# Altium schematic sheet Power.SchDoc(Power)
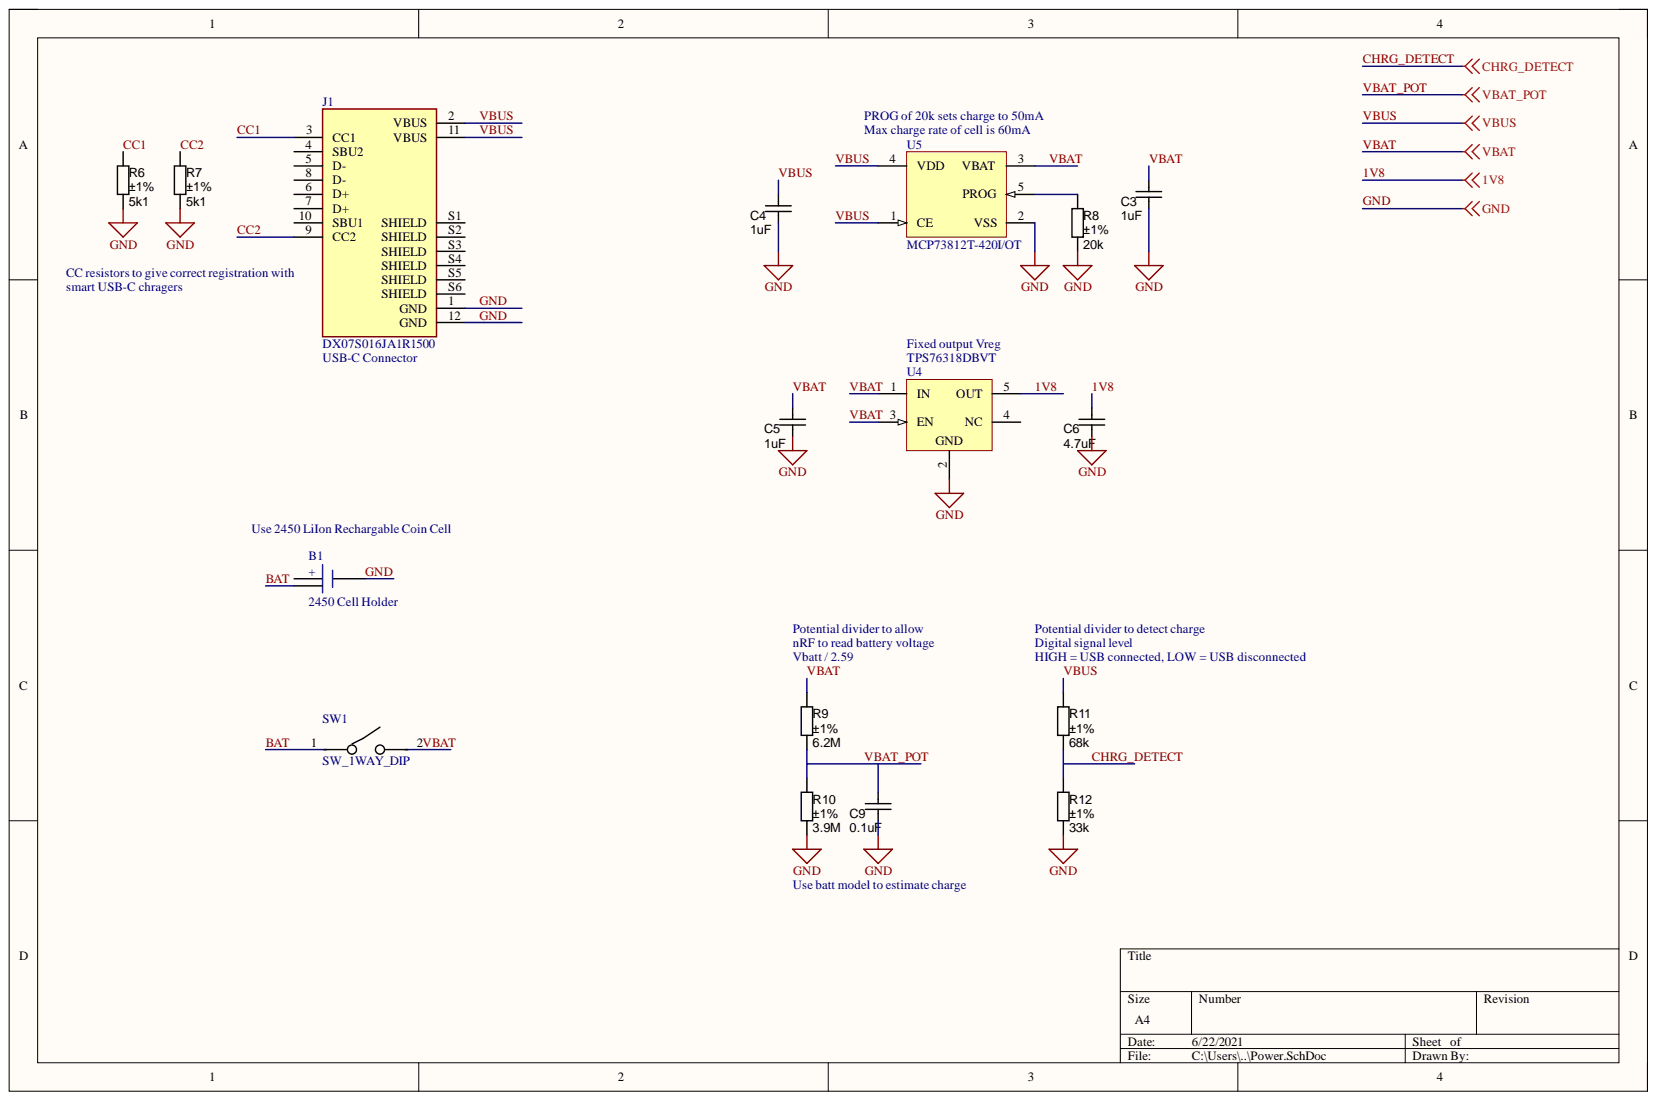
[diagram p0: Power.SchDoc]

## Parts
B1  2450 Cell Holder  [BC-2404]
C3  value 1uF  [CAPC1608X90N]  (hidden comment: approved sub OK)
C4  value 1uF  [CAPC1608X90N]  (hidden comment: approved sub OK)
C5  value 1uF  [CAPC1608X90N]  (hidden comment: approved sub OK)
C6  value 4.7uF  [CAPC1608X90N]  (hidden comment: approved sub OK)
C9  value 0.1uF  [CAPC1608X90N]  (hidden comment: approved sub OK)
J1  DX07S016JA1R1500  [JAE_DX07S016JA1R1500]
R6  value 5k1  Tolerance: ±1%  [RESC1608X55N]  (hidden comment: Thick Film)
R7  value 5k1  Tolerance: ±1%  [RESC1608X55N]  (hidden comment: Thick Film)
R8  value 20k  Tolerance: ±1%  [RESC1608X55N]  (hidden comment: Thick Film)
R9  value 6.2M  Tolerance: ±1%  [RESC1608X55N]  (hidden comment: Thick Film)
R10  value 3.9M  Tolerance: ±1%  [RESC1608X55N]  (hidden comment: Thick Film)
R11  value 68k  Tolerance: ±1%  [RESC1608X55N]  (hidden comment: Thick Film)
R12  value 33k  Tolerance: ±1%  [RESC1608X55N]  (hidden comment: Thick Film)
SW1  SW_1WAY_DIP  [SW_1WAY]
U4  TPS76318DBVT  [TI-DBV5_N]
U5  MCP73812T-420I/OT  [SOT-23-OT5_N]

## Nets
1V8: C6.1 U4.5(OUT)
BAT: B1.3 SW1.1
CC1: J1.3(CC1) R6.1
CC2: J1.9(CC2) R7.1
CHRG_DETECT: R11.2 R12.1
GND: B1.2 C3.2 C4.2 C5.2 C6.2 C9.2 J1.1(GND) J1.12(GND) R6.2 R7.2 R8.2 R10.2 R12.2 U4.2 U5.2(VSS)
VBAT: C3.1 C5.1 R9.1 SW1.2 U4.1(IN) U4.3(EN) U5.3(VBAT)
VBAT_POT: C9.1 R9.2 R10.1
VBUS: C4.1 J1.2(VBUS) J1.11(VBUS) R11.1 U5.1(CE) U5.4(VDD)
NetJ1_8: J1.8(D-)
NetR8_1: R8.1 U5.5(PROG)

Not connected: B1 1; J1 4(SBU2) 5(D-) 6(D+) 7(D+) 10(SBU1) S1(SHIELD) S2(SHIELD) S3(SHIELD) S4(SHIELD) S5(SHIELD) S6(SHIELD); U4 4(NC)

## Library data of the parts
B1 = 2450-LiIon: Multicomp BC-2404; Component Kind: Standard; Library Name: Light Sensor Prototype.SchLib
C3-C6 C9 = CAPC_100n_0603_X7R_50V_10%: Capacitor SMD Cer 10uF 10% 16V X5R 0603; Manufacturer: TDK; Manufacturer Part Number: C1608X5R1C106M080AB; Material: ceramic; Ratings: 16V; X5R; Component Kind: Standard; Package / Case: 0603 (1608 Metric); Supplier: Farnell; Supplier Link: https://uk.farnell.com/tdk/c1608x5r1c106m080ab/cap-10-f-16v-20-x5r-0603/dp/2525096?st=2521959; Supplier Part Number: 2525096; Tolerance: ±10%; Library Name: UEKAE.SCHLIB
J1 = DX07S016JA1R1500: USB-C (USB TYPE-C) USB 2.0 Receptacle Connector 24 (16+8 Dummy) Position Surface Mount, Right Angle; Through Hole; MF: JAE Electronics; Availability: Unavailable; Component Kind: Standard; DigiKey-Purchase-URL: https://snapeda.com/shop?store=DigiKey&id=4551013; Library Name: DX07S016JA1R1500.IntLib; MP: DX07S016JA1R1500; Package: None; Price: None; SnapEDA_Link: https://www.snapeda.com/parts/DX07S016JA1R1500/JAE%20Electronics/view-part/4551013/?ref=snap
R6-R12 = RES_10K_0603_1%: Resistor SMD chip 10k Ohm 0.1W 1% 0603; Manufacturer 1: Yageo; Manufacturer Part Number 1: RC0603FR-0710KL; Ratings: 1/10W; SCDType: spec; Component Kind: Standard; Package / Case: 0603 (1608 Metric); Supplier 1: Digi-Key; Supplier Part Number 1: 311-10.0KHRCT-ND; Library Name: Libs_RRutledge.IntLib
U4 = TPS76318DBVT: Single Output LDO, 150 mA, Fixed 1.8 V Output, 2.7 to 10 V Input, with Low IQ, 5-pin SOT-23 (DBV), -40 to 125 degC, Green (RoHS & no Sb/Br); Manufacturer: Texas Instruments; Accuracy(%): 3; Fixed Output Options(V): 1.8; Iout(Max)(A): 0.15; Iq(Typ)(mA): 0.08; SubFamily: Single Channel LDO; Vdo(Typ)(mV): 300; Vin(Max)(V): 10; Vin(Min)(V): 2.7; Code_JEDEC: MO-178; Component Kind: Standard; ComponentLink1Description: Manufacturer URL; ComponentLink1URL: http://www.ti.com/; ComponentLink2Description: Datasheet; ComponentLink2URL: http://www.ti.com/general/docs/lit/getliterature.tsp?genericPartNumber=TPS76318&fileType=pdf; ComponentLink3Description: Package Specification; ComponentLink3URL: http://www.ti.com/litv/pdf/mpds018i; DatasheetVersion: SLVS181H; Eco-Plan: Green (RoHS & no Sb/Br); Library Name: TI Power Management Linear Regulator Single Channel LDO (0-350mA).IntLib; Mounting Technology: Surface Mount; PackageDescription: 5-Pin Plastic Small Outline, Body 1.60 Ã— 2.90 mm, Pitch 0.95 mm; PackageReference: DBV5; PackageVersion: 4073253-4/K; PartNumber: TPS76318DBVT; Supplier 1: Digi-Key; Supplier 2: Digi-Key; Supplier 3: Digi-Key; Supplier 4: Farnell; Supplier 5: Farnell; Supplier 6: Newark; Supplier 7: Mouser; Supplier Part Number 1: 296-2700-2-ND; Supplier Part Number 2: 296-2700-1-ND; Supplier Part Number 3: 296-2700-6-ND; Supplier Part Number 4: 8461341RL; Supplier Part Number 5: 8461341; Supplier Part Number 6: 96C8608; Supplier Part Number 7: 595-TPS76318DBVT; Vout Adj(Max)(V): 1.8; Vout Adj(Min)(V): 1.8
U5 = MCP73812T-420I/OT: Simple, Miniature Single-Cell, Fully Integrated Li-Ion/Li-Polymer Charge Management Controller, 4.2V, 5-Pin SOT-23, Industrial Temperature, Tape and Reel; Manufacturer: Microchip; Code_IPC: SOT23-5; Component Kind: Standard; ComponentLink1Description: Manufacturer URL; ComponentLink1URL: http://www.microchip.com/; ComponentLink2Description: Datasheet; ComponentLink2URL: http://ww1.microchip.com/downloads/en/DeviceDoc/22036b.pdf; ComponentLink3Description: Package Specification; ComponentLink3URL: http://www.microchip.com/stellent/groups/techpub_sg/documents/packagingspec/en012702.pdf; DatasheetVersion: revB, Sep-2007; Library Name: Microchip Power Management Battery Management.IntLib; Mounting Technology: Surface Mount; PackageDescription: 5-Lead Plastic Small Outline Transistor (OT) [SOT-23]; PackageReference: SOT-23-OT5; PackageVersion: revBB, Aug-2009; PartNumber: MCP73812T-420I/OT; Supplier 1: Digi-Key; Supplier 2: Digi-Key; Supplier 3: Digi-Key; Supplier 4: Farnell; Supplier 5: Farnell; Supplier 6: Newark; Supplier 7: Mouser; Supplier Part Number 1: MCP73812T-420I/OTTR-ND; Supplier Part Number 2: MCP73812T-420I/OTCT-ND; Supplier Part Number 3: MCP73812T-420I/OTDKR-ND; Supplier Part Number 4: 1627187RL; Supplier Part Number 5: 1627187; Supplier Part Number 6: 27M0232; Supplier Part Number 7: 579-MCP73812T-420IOT
SW1 = SW_1WAY_DIP: Component Kind: Standard; Library Name: DFU Connectors.IntLib
